# Altium schematic sheet 04_STORAGE.SchDoc
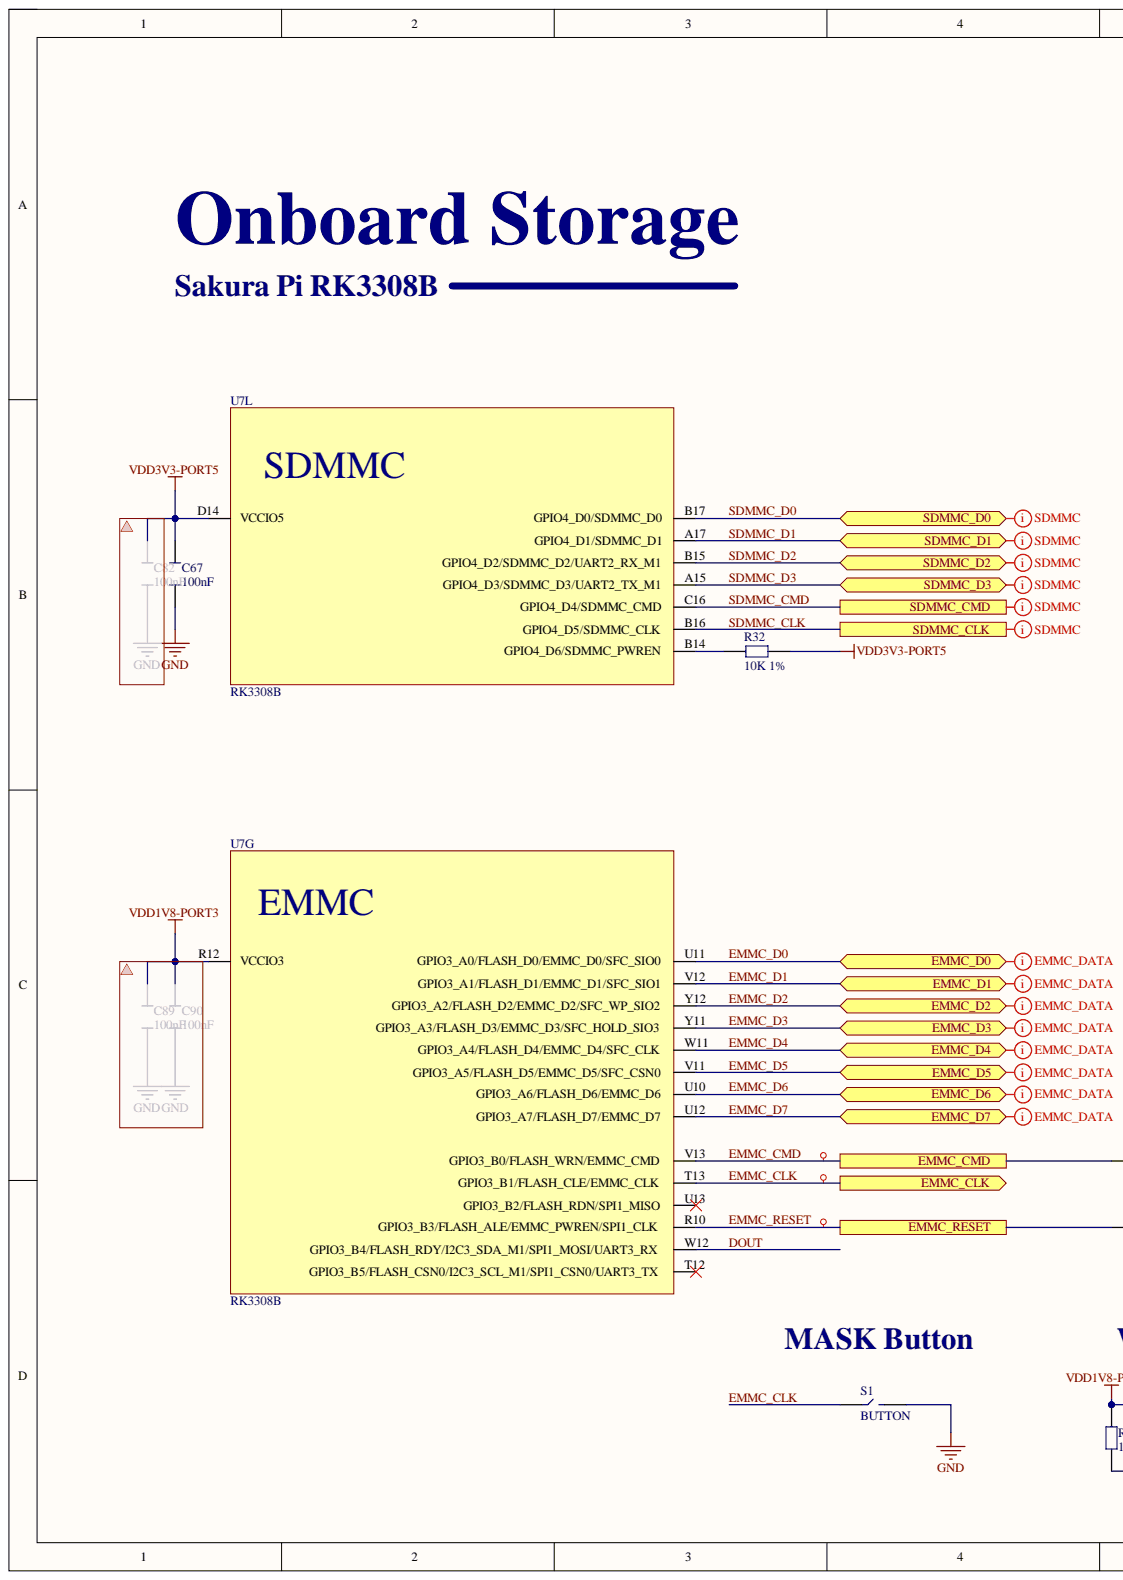
[diagram p0: 04_STORAGE.SchDoc, left]
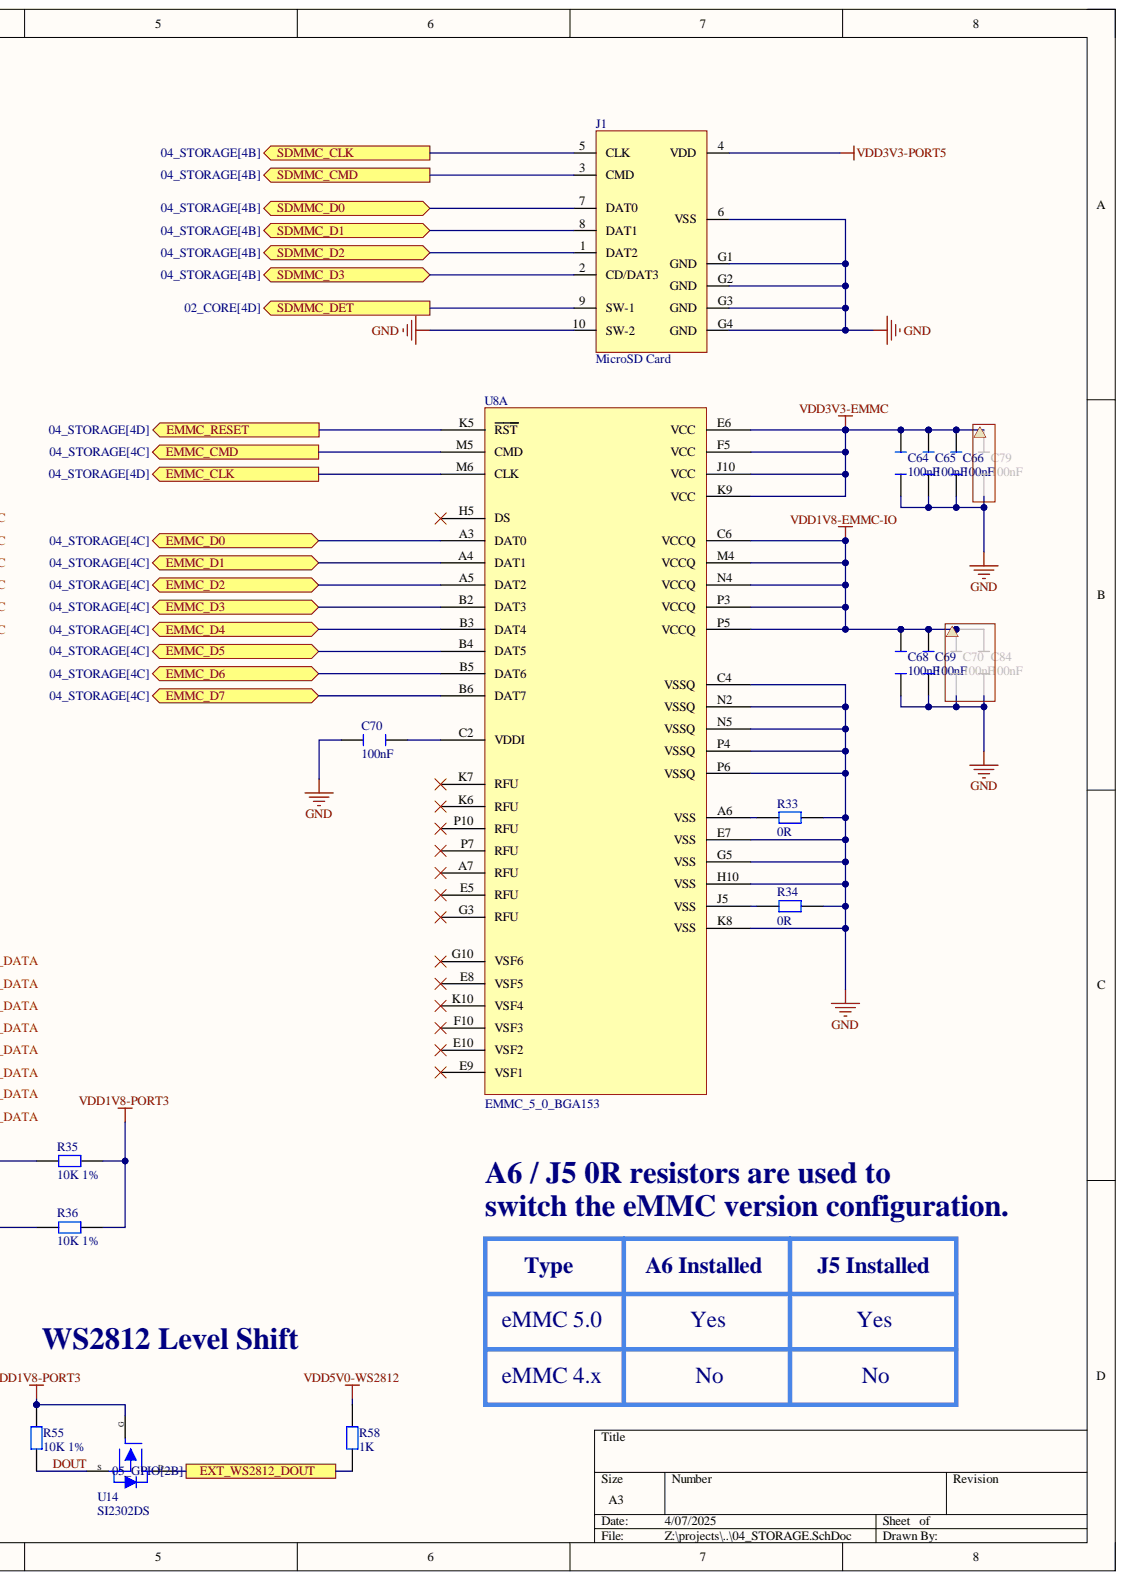
[diagram p1: 04_STORAGE.SchDoc, right]

## Parts
C64  100nF  [C0402]
C65  100nF  [C0402]
C66  100nF  [C0402]
C67  100nF  [C0402]
C68  100nF  [C0402]
C69  100nF  [C0402]
C70  100nF  [C0402]
J1  MicroSD Card  [TFES-E01]
R32  10K 1%  [R0402]
R33  0R  [R0402]
R34  0R  [R0402]
R35  10K 1%  [R0402]
R36  10K 1%  [R0402]
R55  10K 1%  [R0402]
R58  1K  [R0402]
S1  BUTTON  [BUTTON_3X4X2MM]
U7  RK3308B  [RK3308B]  (parts G,L)
U8  EMMC_5_0_BGA153  [EMMC_BGA153]  (part A)
U14  SI2302DS  [SI2302DS]

## Ports
EMMC_CLK, EMMC_CMD, EMMC_D0, EMMC_D1, EMMC_D2, EMMC_D3, EMMC_D4, EMMC_D5, EMMC_D6, EMMC_D7, EMMC_RESET, EXT_WS2812_DOUT, SDMMC_CLK, SDMMC_CMD, SDMMC_D0, SDMMC_D1, SDMMC_D2, SDMMC_D3, SDMMC_DET

## Nets
GND: C64.1 C65.1 C66.1 C67.1 C68.1 C69.1 C70.1 J1.6(VSS) J1.10(SW-2) J1.G1(GND) J1.G2(GND) J1.G3(GND) J1.G4(GND) R33.2 R34.2 S1.2 U8.C4(VSSQ) U8.E7(VSS) U8.G5(VSS) U8.H10(VSS) U8.K8(VSS) U8.N2(VSSQ) U8.N5(VSSQ) U8.P4(VSSQ) U8.P6(VSSQ)
VDD1V8-EMMC-IO: C68.2 C69.2 U8.C6(VCCQ) U8.M4(VCCQ) U8.N4(VCCQ) U8.P3(VCCQ) U8.P5(VCCQ)
VDD1V8-PORT3: R35.1 R36.1 R55.2 U7.R12(VCCIO3) U14.1
VDD3V3-EMMC: C64.2 C65.2 C66.2 U8.E6(VCC) U8.F5(VCC) U8.J10(VCC) U8.K9(VCC)
VDD3V3-PORT5: C67.2 J1.4(VDD) R32.2 U7.D14(VCCIO5)
VDD5V0-WS2812: R58.2
DOUT: R55.1 U7.W12(GPIO3_B4/FLASH_RDY/I2C3_SDA_M1/SPI1_MOSI/UART3_RX) U14.2
EMMC_CLK: S1.1 U7.T13(GPIO3_B1/FLASH_CLE/EMMC_CLK) U8.M6(CLK) ; port EMMC_CLK
EMMC_CMD: R35.2 U7.V13(GPIO3_B0/FLASH_WRN/EMMC_CMD) U8.M5(CMD) ; port EMMC_CMD
EMMC_D0: U7.U11(GPIO3_A0/FLASH_D0/EMMC_D0/SFC_SIO0) U8.A3(DAT0) ; port EMMC_D0
EMMC_D1: U7.V12(GPIO3_A1/FLASH_D1/EMMC_D1/SFC_SIO1) U8.A4(DAT1) ; port EMMC_D1
EMMC_D2: U7.Y12(GPIO3_A2/FLASH_D2/EMMC_D2/SFC_WP_SIO2) U8.A5(DAT2) ; port EMMC_D2
EMMC_D3: U7.Y11(GPIO3_A3/FLASH_D3/EMMC_D3/SFC_HOLD_SIO3) U8.B2(DAT3) ; port EMMC_D3
EMMC_D4: U7.W11(GPIO3_A4/FLASH_D4/EMMC_D4/SFC_CLK) U8.B3(DAT4) ; port EMMC_D4
EMMC_D5: U7.V11(GPIO3_A5/FLASH_D5/EMMC_D5/SFC_CSN0) U8.B4(DAT5) ; port EMMC_D5
EMMC_D6: U7.U10(GPIO3_A6/FLASH_D6/EMMC_D6) U8.B5(DAT6) ; port EMMC_D6
EMMC_D7: U7.U12(GPIO3_A7/FLASH_D7/EMMC_D7) U8.B6(DAT7) ; port EMMC_D7
EMMC_RESET: R36.2 U7.R10(GPIO3_B3/FLASH_ALE/EMMC_PWREN/SPI1_CLK) U8.K5(RST) ; port EMMC_RESET
EXT_WS2812_DOUT: R58.1 U14.3 ; port EXT_WS2812_DOUT
SDMMC_CLK: J1.5(CLK) U7.B16(GPIO4_D5/SDMMC_CLK) ; port SDMMC_CLK
SDMMC_CMD: J1.3(CMD) U7.C16(GPIO4_D4/SDMMC_CMD) ; port SDMMC_CMD
SDMMC_D0: J1.7(DAT0) U7.B17(GPIO4_D0/SDMMC_D0) ; port SDMMC_D0
SDMMC_D1: J1.8(DAT1) U7.A17(GPIO4_D1/SDMMC_D1) ; port SDMMC_D1
SDMMC_D2: J1.1(DAT2) U7.B15(GPIO4_D2/SDMMC_D2/UART2_RX_M1) ; port SDMMC_D2
SDMMC_D3: J1.2(CD/DAT3) U7.A15(GPIO4_D3/SDMMC_D3/UART2_TX_M1) ; port SDMMC_D3
SDMMC_DET: J1.9(SW-1) ; port SDMMC_DET
NetC70_2: C70.2 U8.C2(VDDI)
NetR32_1: R32.1 U7.B14(GPIO4_D6/SDMMC_PWREN)
NetR33_1: R33.1 U8.A6(VSS)
NetR34_1: R34.1 U8.J5(VSS)

Not connected: U7 T12(GPIO3_B5/FLASH_CSN0/I2C3_SCL_M1/SPI1_CSN0/UART3_TX) U13(GPIO3_B2/FLASH_RDN/SPI1_MISO); U8 A7(RFU) E5(RFU) E8(VSF5) E9(VSF1) E10(VSF2) F10(VSF3) G3(RFU) G10(VSF6) H5(DS) K6(RFU) K7(RFU) K10(VSF4) P7(RFU) P10(RFU)

## Library data of the parts
C64-C70 = C_100NF: Component Kind: Standard; Library Name: rk3308b.SchLib
R32 R35 R36 R55 = R_10K_P1: Component Kind: Standard; Library Name: rk3308b.SchLib
R33 R34 = R_0R: Component Kind: Standard; Library Name: rk3308b.SchLib
R58 = R_1K: Component Kind: Standard; Library Name: rk3308b.SchLib
J1 = MicroSD Card: Component Kind: Standard; Library Name: rk3308b.SchLib
S1 = BUTTON: Component Kind: Standard; Library Name: rk3308b.SchLib
U7 = RK3308B: Component Kind: Standard; Library Name: rk3308b.SchLib
U8 = EMMC_5_0_BGA153: Component Kind: Standard; Library Name: rk3308b.SchLib
U14 = SI2302DS: Component Kind: Standard; Library Name: rk3308b.SchLib
